# Altium schematic sheet Beagle Bone Black C Cape CAN.SchDoc
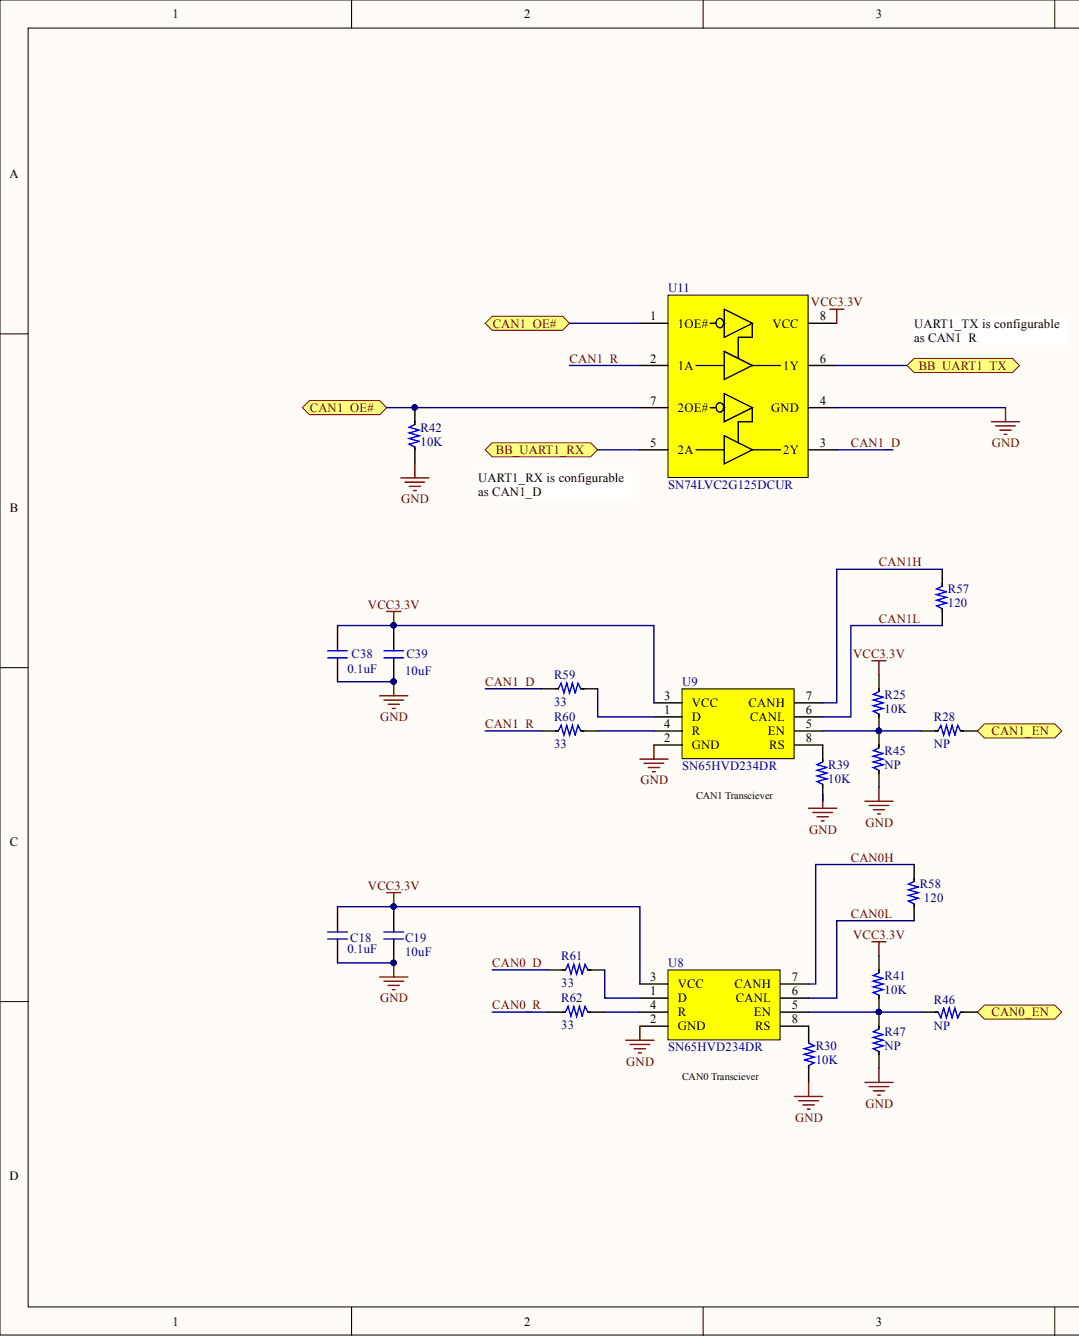
[diagram p0: Beagle Bone Black C Cape CAN.SchDoc, left]
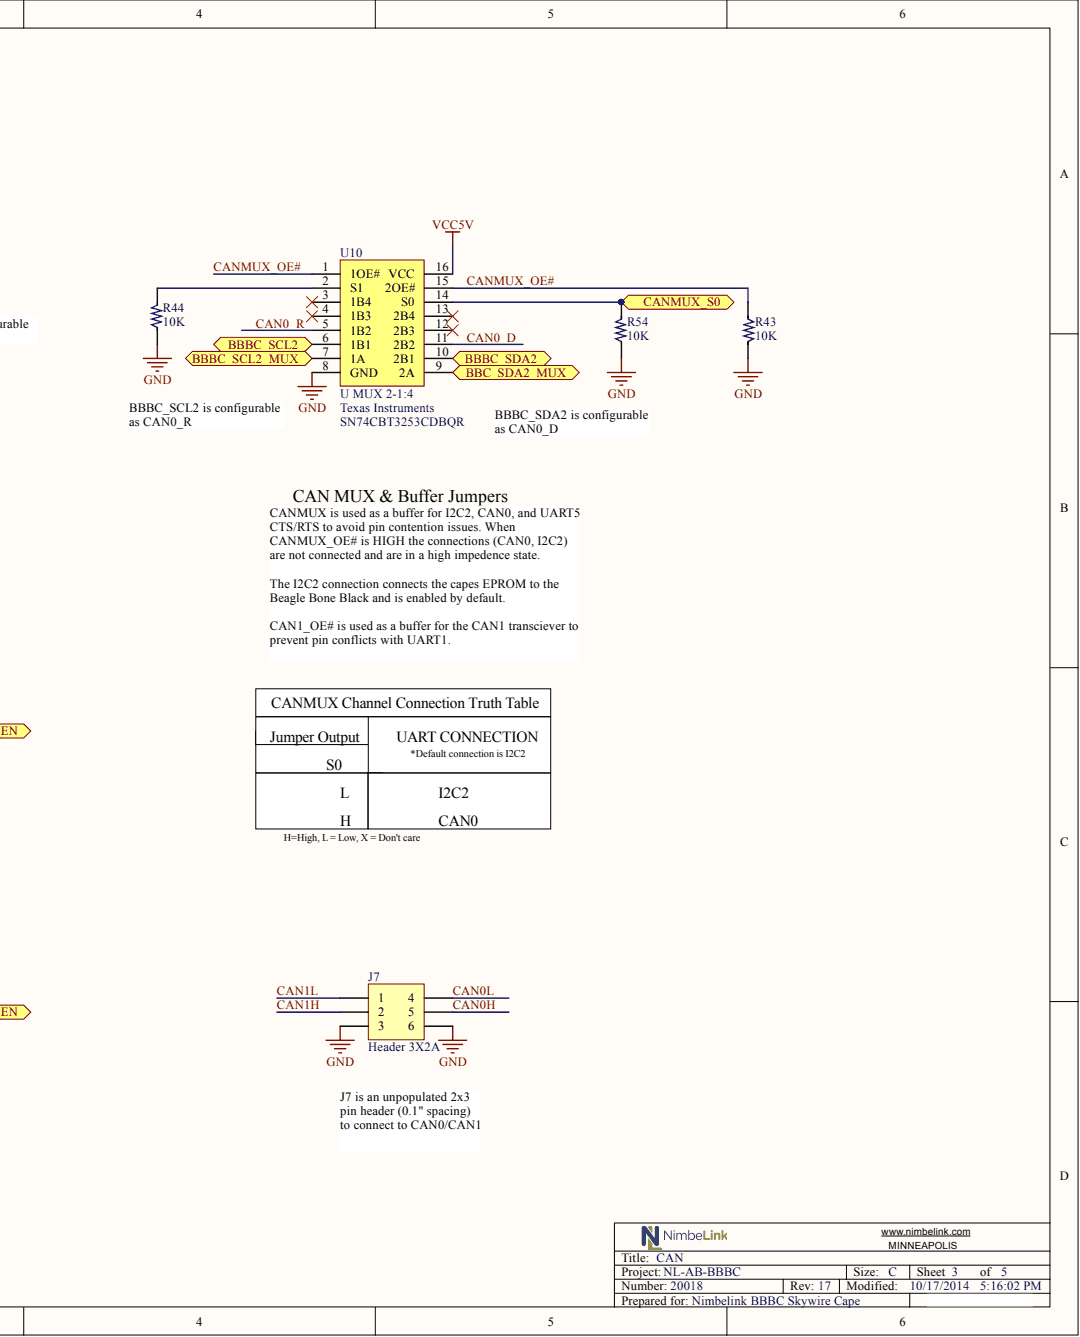
[diagram p1: Beagle Bone Black C Cape CAN.SchDoc, right]

## Parts
C18  0.1uF  [0603]
C19  10uF  [0603]
C38  0.1uF  [0603]
C39  10uF  [0603]
J7  Header 3X2A  [HDR2X3_CEN]
R25  10K  [0603]
R28  NP  [0603]
R30  10K  [0603]
R39  10K  [0603]
R41  10K  [0603]
R42  10K  [0603]
R43  10K  [0603]
R44  10K  [0603]
R45  NP  [0603]
R46  NP  [0603]
R47  NP  [0603]
R54  10K  [0603]
R57  120  [0603]
R58  120  [0603]
R59  33  [0603]
R60  33  [0603]
R61  33  [0603]
R62  33  [0603]
U8  SN65HVD234DR  [SOIC8]
U9  SN65HVD234DR  [SOIC8]
U10  U MUX 2-1:4  Brand: Texas Instruments  [SN74CBT3253CDBQR]
U11  U SN74LVC2G125  [SN74LVC2G125DCUR]  (value not drawn)

## Ports
BB_UART1_RX, BB_UART1_TX, BBBC_SCL2, BBBC_SCL2_MUX, BBBC_SDA2, BBC_SDA2_MUX, CAN0_EN, CAN1_EN, CAN1_OE#, CANMUX_S0

## Nets
GND: C18.2 C19.2 C38.2 C39.2 J7.3 J7.6 R30.2 R39.1 R42.2 R43.1 R44.2 R45.1 R47.1 R54.1 U8.2(GND) U9.2(GND) U10.8(GND) U11.4(GND)
VCC3.3V: C18.1 C19.1 C38.1 C39.1 R25.2 R41.2 U8.3(VCC) U9.3(VCC) U11.8(VCC)
VCC5V: U10.16(VCC)
BB_UART1_RX: U11.5(2A) ; port BB_UART1_RX
BB_UART1_TX: U11.6(1Y) ; port BB_UART1_TX
BBBC_SCL2: U10.6(1B1) ; port BBBC_SCL2
BBBC_SCL2_MUX: U10.7(1A) ; port BBBC_SCL2_MUX
BBBC_SDA2: U10.10(2B1) ; port BBBC_SDA2
BBC_SDA2_MUX: U10.9(2A) ; port BBC_SDA2_MUX
CAN0_D: R61.1 U10.11(2B2)
CAN0_EN: R46.1 ; port CAN0_EN
CAN0_R: R62.1 U10.5(1B2)
CAN0H: J7.5 R58.2 U8.7(CANH)
CAN0L: J7.4 R58.1 U8.6(CANL)
CAN1_D: R59.1 U11.3(2Y)
CAN1_EN: R28.2 ; port CAN1_EN
CAN1_OE#: R42.1 U11.1(1OE#) U11.7(2OE#) ; port CAN1_OE#
CAN1_R: R60.1 U11.2(1A)
CAN1H: J7.2 R57.2 U9.7(CANH)
CAN1L: J7.1 R57.1 U9.6(CANL)
CANMUX_OE#: R43.2 U10.1(1OE#) U10.15(2OE#)
CANMUX_S0: R54.2 U10.14(S0) ; port CANMUX_S0
NetR25_1: R25.1 R28.1 R45.2 U9.5(EN)
NetR30_1: R30.1 U8.8(RS)
NetR39_2: R39.2 U9.8(RS)
NetR41_1: R41.1 R46.2 R47.2 U8.5(EN)
NetR44_1: R44.1 U10.2(S1)
NetR59_2: R59.2 U9.1(D)
NetR60_2: R60.2 U9.4(R)
NetR61_2: R61.2 U8.1(D)
NetR62_2: R62.2 U8.4(R)

Not connected: U10 3(1B4) 4(1B3) 12(2B3) 13(2B4)

## Library data of the parts
C18 C38 = Cap 0.1uF 16V 10% X7R 0603 CER: Manufacturer 1: Samsung Electro-Mechanics America, Inc; Manufacturer Part Number 1: CL10B104KO8NNNC; Capacitance: 0.1µF; Applications: General Purpose; Category: Capacitors; Component Kind: Standard; ComponentLink1Description: http://www.samsungsem.com/servlet/FileDownload?type=spec&file=CL10B104KO8NNNC.pdf; ComponentLink1URL: http://www.samsungsem.com/servlet/FileDownload?type=spec&file=CL10B104KO8NNNC.pdf; Description: Cap 0.1uF 16V 10% X7R 0603 CER; Features: -; Height - Seated (Max): -; Lead Spacing: -; Lead Style: -; Mounting Type: Surface Mount, MLCC; Operating Temperature: -55°C ~ 125°C; Package / Case: 0603 (1608 Metric); Packaging: Cut Tape (CT); Pricing: 1=0.1, 10=0.019, 25=0.0132, 50=0.0102, 100=0.0086, 250=0.00704, 500=0.006, 1000=0.00468 (USD); Ratings: -; RoHS: RoHS Compliant; Size / Dimension: 0.063" L x 0.031" W (1.60mm x 0.80mm); Stock: 1252951; Supplier 1: Digi-Key; Supplier Part Number 1: 1276-1005-1-ND; Temperature Coefficient: X7R; Thickness (Max): 0.035" (0.90mm); Tolerance: ±10%; Voltage - Rated: 16V; Library Name: Nimbelink Altium Lib.SchLib
C19 C39 = Cap 10uF 10V 10% X5R 0603 CER: Component Kind: Standard; Description: Cap 10uF 10V 10% X5R 0603 CER; Supplier 1: Digi-Key; Supplier Part Number 1: 445-7486-1-ND; Library Name: Nimbelink Altium Lib.SchLib
J7 = Header 3X2A: Header, 3-Pin, Dual row; Component Kind: Standard; LatestRevisionDate: 17-Jul-2002; LatestRevisionNote: Re-released for DXP Platform.; Library Name: Miscellaneous Connectors.IntLib; Signal Integrity: Connector; Publisher: Altium Limited
R25 R30 R39 R41-R44 R54 = RES 10K 1/10W 1% 0603: Brand: Panasonic; Case Height: 0.45 mm; Case Length: 1.6 mm; Case Width: 0.8 mm; Power Rating: 100 mW; Resistance: 10 kOhms; Temperature Coefficient: 100 PPM / C; Voltage Rating: 75 V; Case Code - in: 0603; Case Code - mm: 1608; Category: Thick Film Resistors - SMD; Component Kind: Standard; ComponentLink1Description: http://industrial.panasonic.com/www-data/pdf/AOA0000/AOA0000CE2.pdf; ComponentLink1URL: http://industrial.panasonic.com/www-data/pdf/AOA0000/AOA0000CE2.pdf; Description: RES 10K 1/10W 1% 0603; Manufacturer 2: Panasonic; Manufacturer Part Number 2: ERJ-3EKF1002V; Minimum Order Quantity: 1; Operating Temperature Range: - 55 C to + 155 C; Package / Case: 0603 (1608 metric); Packaging: Reel; Pricing: 1=0.135, 10=0.019, 100=0.012, 500=0.009, 1000=0.005, 5000=0.004, 25000=0.003 (AUD); Product: Thick Film Resistors SMD; Reeling: True; RoHS: RoHS Compliant By Exemption; RoHS - Mouser: E; Series: ERJ; Standard Pack Qty: 5000; Stock: 1717350; Supplier 1: Digi-Key; Supplier 2: Mouser; Supplier Part Number 1: P10.0KHCT-ND; Supplier Part Number 2: 667-ERJ-3EKF1002V; Tolerance: 1 %; Type: Precision Thick Film Resistors SMD; Library Name: Nimbelink Altium Lib.SchLib
R28 R45-R47 = RES NP: Component Kind: Standard; Description: NP; Library Name: Nimbelink Altium Lib.SchLib
R57 R58 = RES 120 1/10W 1% 0603: Component Kind: Standard; Description: RES 120 1/10W 1% 0603; Library Name: Nimbelink Altium Lib.SchLib
R59-R62 = RES 33 1/10W 1% 0603: Component Kind: Standard; Description: RES 33 1/10W 1% 0603; Library Name: Nimbelink Altium Lib.SchLib
U8 U9 = U SN65HVD234D: SN65HVD234D CAN Transciever; Manufacturer 1: Texas Instruments; Data Rate: 1Mbps; Duplex: Half; Number of Drivers/Receivers: 1/1; Receiver Hysteresis: 100mV; Voltage - Supply: 3 V ~ 3.6 V; Category: Integrated Circuits (ICs); Component Kind: Standard; ComponentLink1Description: http://www.ti.com/lit/ds/symlink/sn65hvd233.pdf; ComponentLink1URL: http://www.ti.com/lit/ds/symlink/sn65hvd233.pdf; Manufacturer Part Number 1: SN65HVD234DR; Mounting Type: Surface Mount; Operating Temperature: -40°C ~ 125°C; Package / Case: 8-SOIC (0.154", 3.90mm Width); Packaging: Cut Tape (CT); Pricing: 1=2.73, 10=2.462, 25=2.206, 100=1.9836, 250=1.76132, 500=1.539, 750=1.39365, 1000=1.06875 (USD); Protocol: CAN; RoHS: RoHS Compliant; Stock: 15839; Supplier 1: Digi-Key; Supplier Device Package: 8-SOIC; Supplier Part Number 1: 296-27991-1-ND; Type: Transceiver; Library Name: Nimbelink Altium Lib.SchLib
U10 = U MUX 2-1:4: Number of Channels: 1 Channel; Number of Switches: 2; On Resistance - Max: 12 Ohms; Operating Supply Current: 3 uA; Operating Supply Voltage: 4 V to 5.5 V; Propagation Delay Time: 0.15 ns; Switch Configuration: SPDT; Category: Multiplexer Switch ICs; Component Kind: Standard; ComponentLink1Description: http://www.ti.com/litv/scds123b; ComponentLink1URL: http://www.ti.com/litv/scds123b; Manufacturer 1: Texas Instruments; Manufacturer Part Number 1: SN74CBT3253CDBQR; Maximum Operating Temperature: + 85 C; Minimum Operating Temperature: - 40 C; Minimum Order Quantity: 1; Mounting Style: SMD/SMT; Package / Case: SSOP-16; Packaging: Reel; Pricing: 1=0.891, 10=0.711, 100=0.531, 500=0.390, 1000=0.356, 2500=0.301, 10000=0.289, 25000=0.278, 50000=0.267 (AUD); Product Category: Logic - Signal Switches; Reeling: True; RoHS: RoHS Compliant; RoHS - Mouser: Y; Series: SN74CBT3253C; Standard Pack Qty: 2500; Stock: 7460; Supplier 1: Mouser; Supplier 2: Digi-Key; Supplier Part Number 1: 595-SN74CBT3253CDBQR; Supplier Part Number 2: 296-19204-1-ND; Library Name: Nimbelink Altium Lib.SchLib
U11 = U SN74LVC2G125: Manufacturer 1: Texas Instruments; Current - Output High, Low: 32mA, 32mA; Logic Type: Buffer/Line Driver, Non-Inverting; Number of Bits per Element: 1; Number of Elements: 2; Voltage - Supply: 1.65 V ~ 5.5 V; Category: Integrated Circuits (ICs); Component Kind: Standard; ComponentLink1Description: http://www.ti.com/lit/ds/symlink/sn74lvc2g125.pdf; ComponentLink1URL: http://www.ti.com/lit/ds/symlink/sn74lvc2g125.pdf; Manufacturer Part Number 1: SN74LVC2G125DCUR; Mounting Type: Surface Mount; Operating Temperature: -40°C ~ 125°C; Package / Case: 8-VFSOP (0.091", 2.30mm Width); Packaging: Cut Tape (CT); Pricing: 1=0.7, 10=0.614, 25=0.5424, 100=0.4726, 250=0.4114, 500=0.3502, 750=0.306, 1000=0.2805 (USD); RoHS: RoHS Compliant; Stock: 37593; Supplier 1: Digi-Key; Supplier Device Package: US8; Supplier Part Number 1: 296-13265-1-ND; Library Name: Nimbelink Altium Lib.SchLib
